# Altium schematic sheet TMC5160_BOB_V1_2.SchDoc(TMC5160_BOB_V1_2)
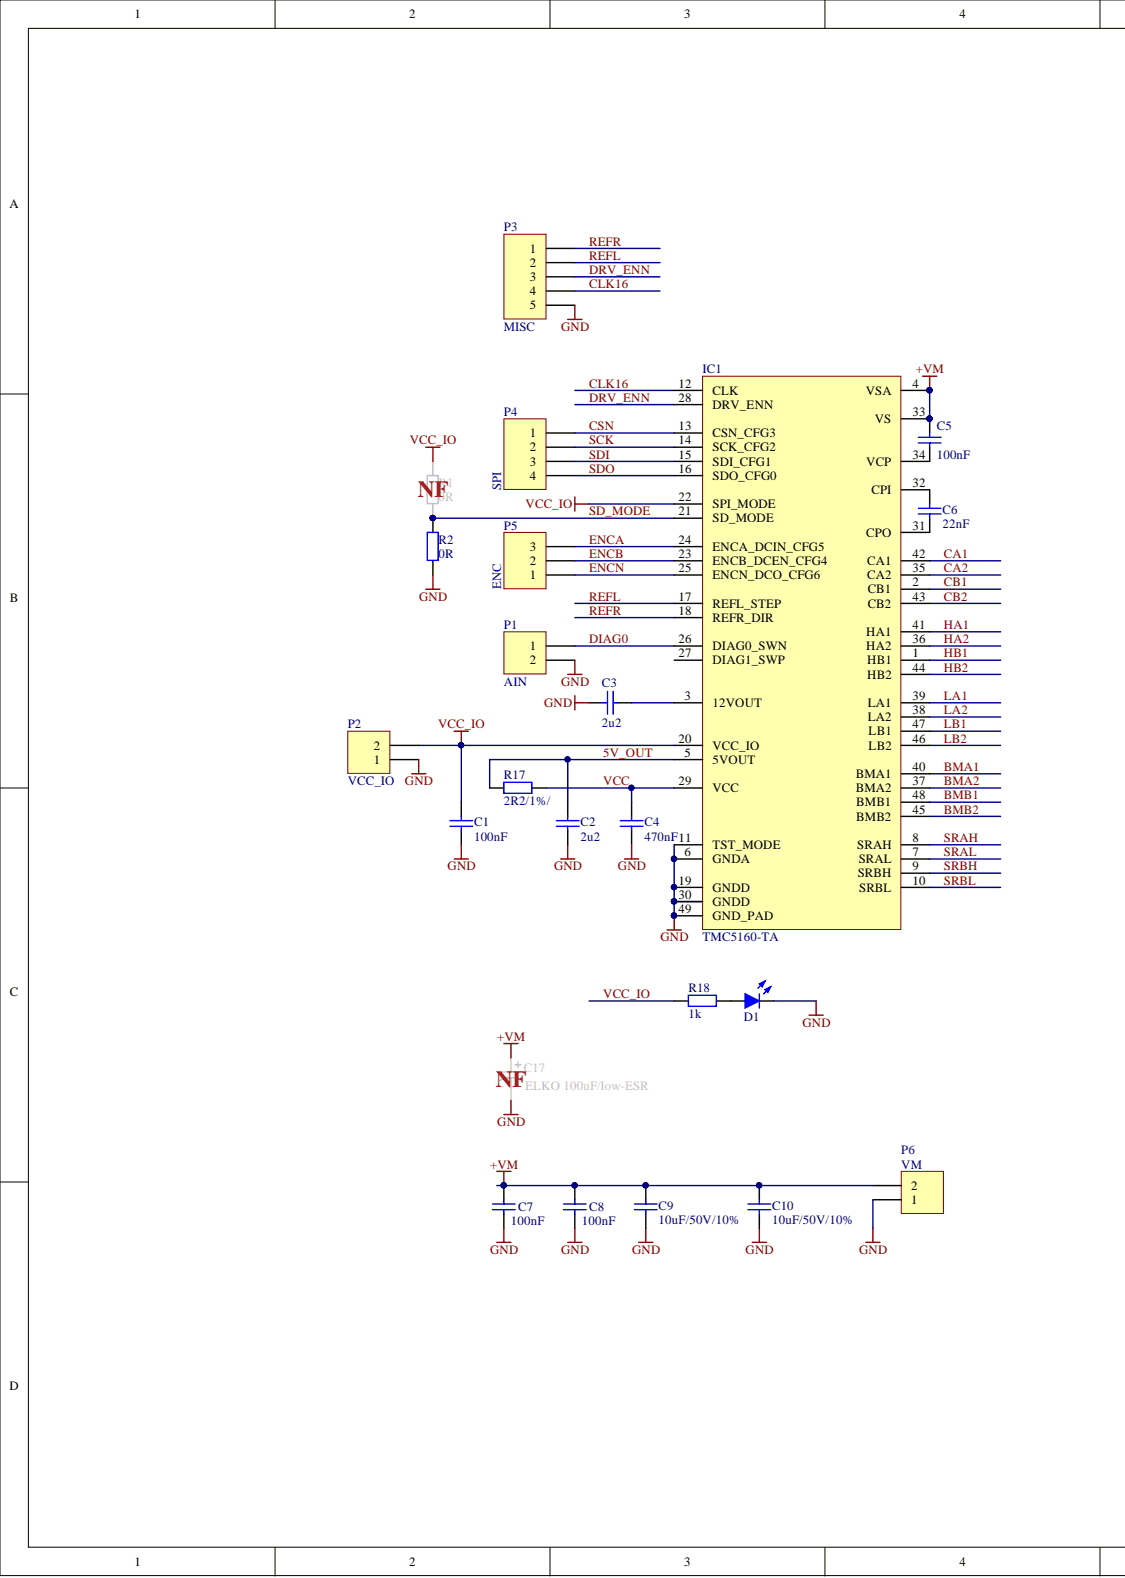
[diagram p0: TMC5160_BOB_V1_2.SchDoc, left]
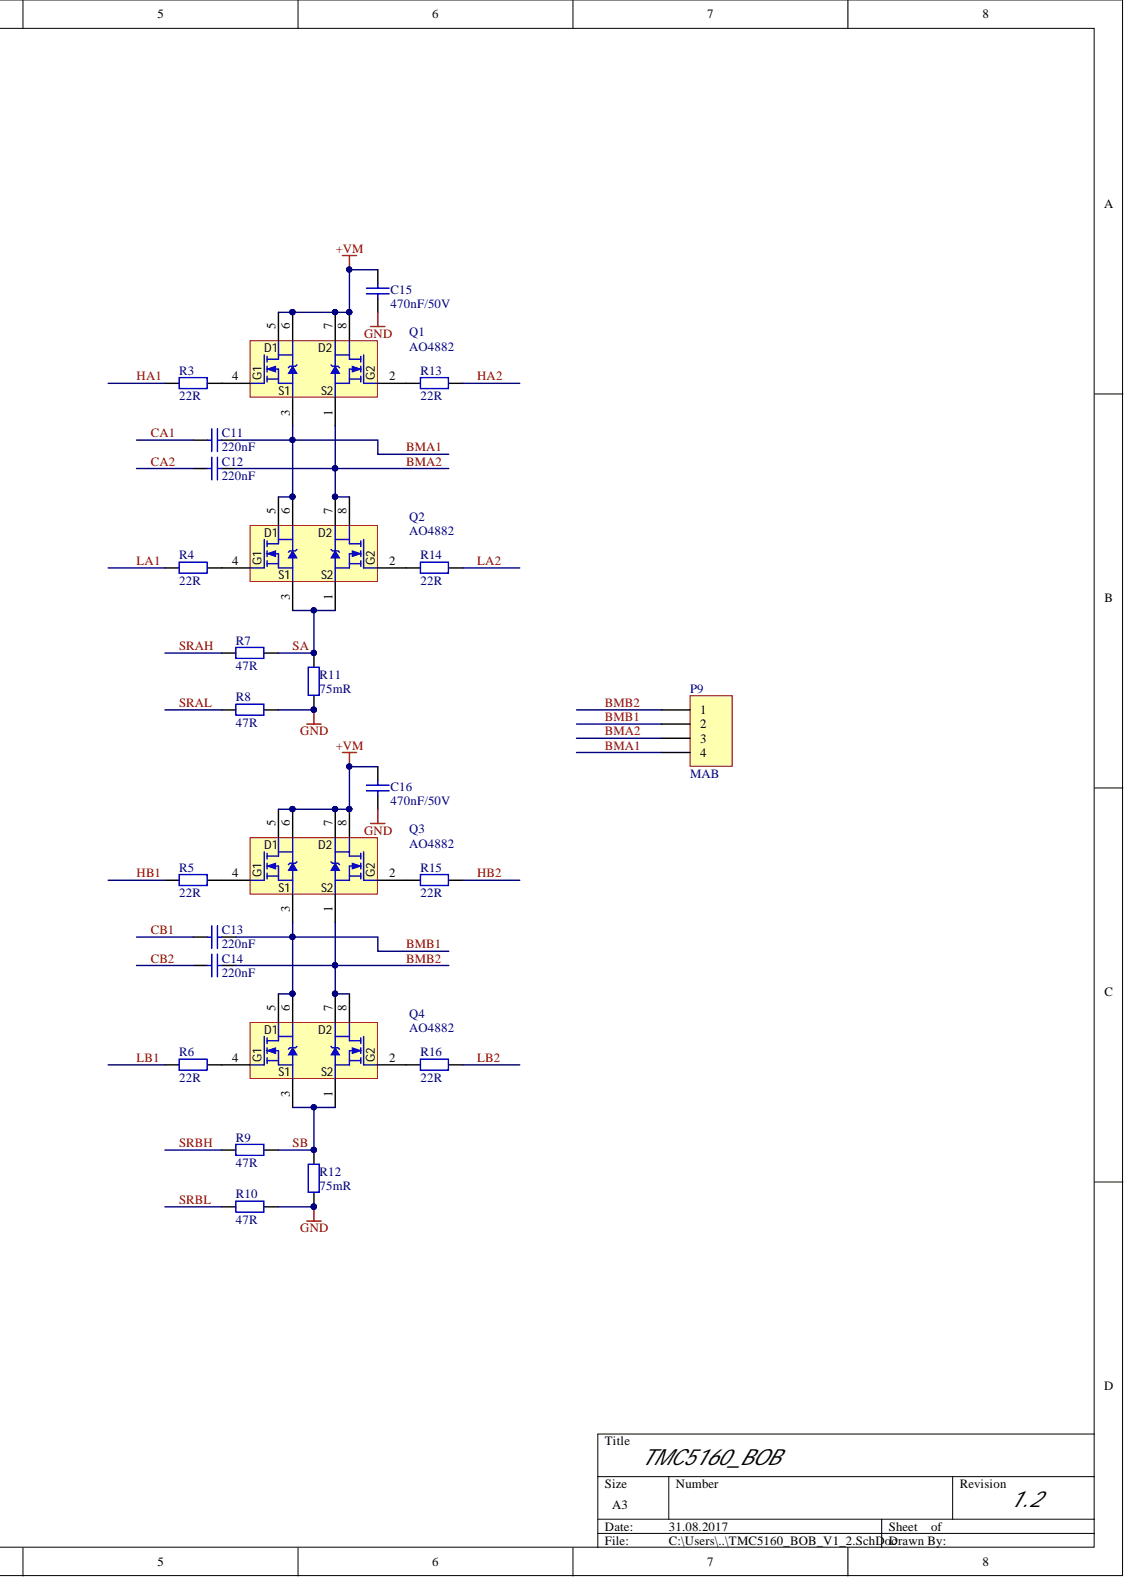
[diagram p1: TMC5160_BOB_V1_2.SchDoc, right]

## Parts
C1  100nF  [C0603]
C2  2u2  [C0603]
C3  2u2  [C0603]
C4  470nF  [C0603]
C5  100nF  [C0603]
C6  22nF  [C0603]
C7  100nF  [C0603]
C8  100nF  [C0603]
C9  10uF/50V/10%  [C1206]
C10  10uF/50V/10%  [C1206]
C11  220nF  [C0603]
C12  220nF  [C0603]
C13  220nF  [C0603]
C14  220nF  [C0603]
C15  470nF/50V  [C0603]
C16  470nF/50V  [C0603]
C17  value ELKO 100uF/low-ESR  [EXT_POL_CAP]  (hidden comment: Cap Pol1)
D1  LED, Blue, SMD, 20mA, 2.8V, 465 nm  [LED_0603]  (value not drawn)
IC1  TMC5160-TA  [TMC5160_TSQFP50P900X900X120_HS-49L]
P1  AIN  [2_PIN_THT]
P2  VCC_IO  [2_PIN_THT]
P3  MISC  [5_PIN_THT]
P4  SPI  [4_PIN_THT]
P5  ENC  [3_PIN_THT]
P6  VM  [2_PIN_THT]
P9  MAB  [4_PIN_THT_1mm_hole]
Q1  AO4882  [SOP127P600X170-8L]
Q2  AO4882  [SOP127P600X170-8L]
Q3  AO4882  [SOP127P600X170-8L]
Q4  AO4882  [SOP127P600X170-8L]
R1  0R  [R0603]
R2  0R  [R0603]
R3  Resistance: 22R  [R0603]  (hidden comment: 22R / 100mW / 1%)
R4  Resistance: 22R  [R0603]  (hidden comment: 22R / 100mW / 1%)
R5  Resistance: 22R  [R0603]  (hidden comment: 22R / 100mW / 1%)
R6  Resistance: 22R  [R0603]  (hidden comment: 22R / 100mW / 1%)
R7  Resistance: 47R  [R0603]  (hidden comment: 47R /  / 1%)
R8  Resistance: 47R  [R0603]  (hidden comment: 47R /  / 1%)
R9  Resistance: 47R  [R0603]  (hidden comment: 47R /  / 1%)
R10  Resistance: 47R  [R0603]  (hidden comment: 47R /  / 1%)
R11  75mR  [R1206]
R12  75mR  [R1206]
R13  Resistance: 22R  [R0603]  (hidden comment: 22R / 100mW / 1%)
R14  Resistance: 22R  [R0603]  (hidden comment: 22R / 100mW / 1%)
R15  Resistance: 22R  [R0603]  (hidden comment: 22R / 100mW / 1%)
R16  Resistance: 22R  [R0603]  (hidden comment: 22R / 100mW / 1%)
R17  2R2/1%/  [R0603]
R18  1k  [R0603]

## Nets
+VM: C5.1 C7.1 C8.1 C9.2 C10.2 C15.1 C16.1 C17.1 IC1.4(VSA) IC1.33(VS) P6.2 Q1.5 Q1.6 Q1.7 Q1.8 Q3.5 Q3.6 Q3.7 Q3.8
GND: C1.2 C2.2 C3.1 C4.2 C7.2 C8.2 C9.1 C10.1 C15.2 C16.2 C17.2 D1.2 IC1.6(GNDA) IC1.11(TST_MODE) IC1.19(GNDD) IC1.30(GNDD) IC1.49(GND_PAD) P1.2 P2.1 P3.5 P6.1 R2.2 R8.1 R10.1 R11.2 R12.2
5V_OUT: C2.1 IC1.5(5VOUT) R17.1
BMA1: C11.2 IC1.40(BMA1) P9.4 Q1.3 Q2.5 Q2.6
BMA2: C12.2 IC1.37(BMA2) P9.3 Q1.1 Q2.7 Q2.8
BMB1: C13.2 IC1.48(BMB1) P9.2 Q3.3 Q4.5 Q4.6
BMB2: C14.2 IC1.45(BMB2) P9.1 Q3.1 Q4.7 Q4.8
CA1: C11.1 IC1.42(CA1)
CA2: C12.1 IC1.35(CA2)
CB1: C13.1 IC1.2(CB1)
CB2: C14.1 IC1.43(CB2)
CLK16: IC1.12(CLK) P3.4
CSN: IC1.13(CSN_CFG3) P4.1
DIAG0: IC1.26(DIAG0_SWN) P1.1
DRV_ENN: IC1.28(DRV_ENN) P3.3
ENCA: IC1.24(ENCA_DCIN_CFG5) P5.3
ENCB: IC1.23(ENCB_DCEN_CFG4) P5.2
ENCN: IC1.25(ENCN_DCO_CFG6) P5.1
HA1: IC1.41(HA1) R3.2
HA2: IC1.36(HA2) R13.1
HB1: IC1.1(HB1) R5.2
HB2: IC1.44(HB2) R15.1
LA1: IC1.39(LA1) R4.2
LA2: IC1.38(LA2) R14.1
LB1: IC1.47(LB1) R6.2
LB2: IC1.46(LB2) R16.1
REFL: IC1.17(REFL_STEP) P3.2
REFR: IC1.18(REFR_DIR) P3.1
SA: Q2.1 Q2.3 R7.1 R11.1
SB: Q4.1 Q4.3 R9.1 R12.1
SCK: IC1.14(SCK_CFG2) P4.2
SD_MODE: IC1.21(SD_MODE) R1.2 R2.1
SDI: IC1.15(SDI_CFG1) P4.3
SDO: IC1.16(SDO_CFG0) P4.4
SRAH: IC1.8(SRAH) R7.2
SRAL: IC1.7(SRAL) R8.2
SRBH: IC1.9(SRBH) R9.2
SRBL: IC1.10(SRBL) R10.2
VCC: C4.1 IC1.29(VCC) R17.2
VCC_IO: C1.1 IC1.20(VCC_IO) IC1.22(SPI_MODE) P2.2 R1.1 R18.1
NetC3_2: C3.2 IC1.3(12VOUT)
NetC5_2: C5.2 IC1.34(VCP)
NetC6_1: C6.1 IC1.31(CPO)
NetC6_2: C6.2 IC1.32(CPI)
NetD1_1: D1.1 R18.2
NetQ1_2: Q1.2 R13.2
NetQ1_4: Q1.4 R3.1
NetQ2_2: Q2.2 R14.2
NetQ2_4: Q2.4 R4.1
NetQ3_2: Q3.2 R15.2
NetQ3_4: Q3.4 R5.1
NetQ4_2: Q4.2 R16.2
NetQ4_4: Q4.4 R6.1
N00027:

Not connected: IC1 27(DIAG1_SWP)

## Library data of the parts
C1 C7 C8 = Capacitor: Ceramic capacitor; MPN: MC0603F104M500CT; Manufacturer: Multicomp; Voltage rating: 50V; Type: MLCC; Precision: 20%; ComponentLinknDescription: Datasheet; Library Name: SCH Passives.SchLib; Component Kind: Standard; Capacitance: 100nF
C2 C3 = 2.2 µF, 25 V, ± 10%, X5R, GRM Series: SMD Multilayer Ceramic Capacitor, 0603 [1608 Metric], 2.2 µF, 25 V, ± 10%, X5R, GRM Series; MPN: GRM188R61E225KA12D; Voltage rating: 50V; Type: MLCC; MF: MURATA; ComponentLinknDescription: Datasheet; Precision: 10%; Library Name: TMC5160_RevA.SchLib; Component Kind: Standard; Capacitance: 2u2
C4 = Capacitor: Ceramic capacitor; MPN: MC0603X474K160CT; Voltage rating: 16V; Type: MLCC; Precision: 10%; MF: Multicomp; ComponentLinknDescription: Datasheet; Library Name: SCH Passives.SchLib; Component Kind: Standard; Capacitance: 470nF
C5 = Capacitor: Ceramic capacitor; MPN: MC0603F104M500CT; Voltage rating: 50V; Type: MLCC; Precision: 20%; MF: Multicomp; ComponentLinknDescription: Datasheet; Library Name: SCH Passives.SchLib; Component Kind: Standard; Capacitance: 100nF
C6 = Capacitor: Ceramic capacitor; MPN: MC0603B223K500CT; Voltage rating: 50V; Type: MLCC; Precision: 20%; MF: Multicomp; ComponentLinknDescription: Datasheet; Library Name: SCH Passives.SchLib; Component Kind: Standard; Capacitance: 22nF
C9 C10 = 10 µF, 50 V, ± 20%, X5R, GRM Series: SMD Multilayer Ceramic Capacitor, 1206 [3216 Metric], 10 µF, 50 V, ± 20%, X5R, GRM Series; MPN: GRM31CR61H106MA12L; VoltageRating: 50V; Type: MLCC; Precision: 10%; MF: MURATA; ComponentLinknDescription: Datasheet; Capacitance: 10uF; Library Name: TMC262_FDD8424H_RevA.SchLib; Component Kind: Standard
C11-C14 = 0.22 µF, 50 V, ± 10%, X7R: SMD Multilayer Ceramic Capacitor, 0603 [1608 Metric], 0.22 µF, 50 V, ± 10%, X7R, GCM Series; MPN: GCM188R71H224KA64D; Voltage rating: 50V; Type: MLCC; MF: MURATA; ComponentLinknDescription: Datasheet; Precision: 10%; Library Name: TMC5160_RevA.SchLib; Component Kind: Standard; Capacitance: 220nF
C15 C16 = 0.47 µF, 50 V, ± 10%, X7R, C Series: SMD Multilayer Ceramic Capacitor, 0603 [1608 Metric], 0.47 µF, 50 V, ± 10%, X7R, C Series; MPN: C1608X7R1H474K080AC; VoltageRating: 50V; Type: MLCC; MF: TDK; ComponentLinknDescription: Datasheet; Capacitance: 470nF; Precision: 10%; Library Name: TMC5160_RevA.SchLib; Component Kind: Standard
C17 = Cap Pol1: Polarized Capacitor (Radial); Published: 8-Jun-2000; PackageReference: RB7.6-15; PackageDescription: Polarized Capacitor (Radial); 2 Leads; Library Name: Miscellaneous Devices.IntLib; LatestRevisionNote: Re-released for DXP Platform.; LatestRevisionDate: 17-Jul-2002; Component Kind: Standard; Publisher: Altium Limited
D1 = LED, Blue, SMD, 20mA, 2.8V, 465 nm: MPN: LTST-C191TBKT-5A; MF: Lite-On; Library Name: TMC26x_RevA.SchLib; Description: LED, Blue, SMD, 20mA, 2.8V, 465 nm; Current rating: 20mA; Component Kind: Standard; Colour: Blue
IC1 = TMC5160-TA: MF: TRINAMIC; Geometry.Height: 1,2mm; MPN: TMC5160-TA; Manufacturer: TRINAMIC; Library Name: TMC5160_RevA.SchLib; Distributor#1: Digikey; Component Kind: Standard
P1 P2 P6 = Header 2: Header, 2-Pin; Fitted: Not fitted; Signal Integrity: Connector; Library Name: Miscellaneous Connectors.IntLib; LatestRevisionNote: Re-released for DXP Platform.; LatestRevisionDate: 17-Jul-2002; Component Kind: Standard; ComponentClass: Pinheader; Publisher: Altium Limited
P3 = Header 5: Header, 5-Pin; Fitted: Not fitted; Signal Integrity: Connector; Library Name: Miscellaneous Connectors.IntLib; LatestRevisionNote: Re-released for DXP Platform.; LatestRevisionDate: 17-Jul-2002; Component Kind: Standard; Publisher: Altium Limited
P4 = Header 4: Header, 4-Pin; Fitted: Not fitted; Signal Integrity: Connector; Library Name: Miscellaneous Connectors.IntLib; LatestRevisionNote: Re-released for DXP Platform.; LatestRevisionDate: 17-Jul-2002; Component Kind: Standard; ComponentClass: Pinheader; Publisher: Altium Limited
P5 = Header 3: Header, 3-Pin; Fitted: Not fitted; Signal Integrity: Connector; Library Name: Miscellaneous Connectors.IntLib; LatestRevisionNote: Re-released for DXP Platform.; LatestRevisionDate: 17-Jul-2002; Component Kind: Standard; Publisher: Altium Limited
P9 = Wire-To-Board Connector, 2.5 mm, 4 Contacts, Header, EH Series, Through Hole, 1 Rows: MPN: B4B-EH-A; MF: JST (JAPAN SOLDERLESS TERMINALS); Fitted: Not fitted; Signal Integrity: Connector; Library Name: TMC5160_RevA.SchLib; Description: Wire-To-Board Connector, 2.5 mm, 4 Contacts, Header, EH Series, Through Hole, 1 Rows; Component Kind: Standard
Q1-Q4 = AO4882 MOSFET 2N-CH 40V 8A 8SOIC: Mosfet Array 2 N-Channel (Dual) 40V 8A 2W Surface Mount 8-SOIC; MF: Alpha & Omega Semiconductor Inc.; MPN: AO4882; Library Name: TMC5160_RevA.SchLib; Component Kind: Standard
R1 R2 = 0 ohm, 0603 [1608 Metric], 100 mW, MCWR Series: SMD Chip Resistor, Thick Film, 0 ohm, 0603 [1608 Metric], 100 mW, MCWR Series; MPN: MCWR06X000 PTL; Temp coefficient: *ppm; PowerRating: 100mW; MF: MULTICOMP; Resistance: 0R; Library Name: TMC5160_RevA.SchLib; Component Kind: Standard
R3-R6 R13-R16 = 22 ohm, 50 V, 0603 [1608 Metric], 100 mW, ± 1%, MCWR Series: SMD Chip Resistor, Thick Film, 22 ohm, 50 V, 0603 [1608 Metric], 100 mW, ± 1%, MCWR Series; MPN: MCWR06X22R0FTLV; Temp coefficient: *ppm; MF: MULTICOMP; Precision: 1%; PowerRating: 100mW; Library Name: TMC5160_RevA.SchLib; Component Kind: Standard
R7-R10 = 47 ohm, 75 V, 0603 [1608 Metric], 100 mW, ± 1%, MCSR 06 Series: SMD Chip Resistor, Ceramic, 47 ohm, 75 V, 0603 [1608 Metric], 100 mW, ± 1%, MCSR 06 Series; MPN: MCSR06X47R0FTL; Temp coefficient: *ppm; MF: MULTICOMP; Precision: 1%; Library Name: TMC5160_RevA.SchLib; Component Kind: Standard
R11 R12 = R_SENS, 0.075 ohm, 1206, 1W, ± 1%, ERJ Series: SMD Chip Resistor, Thick Film, Current Sense Resistors - SMD 1W 0.075ohm 1%; MPN: ERJ-8BWFR075V; VoltageRating: 50V; Temp coefficient: *ppm; MF: Panasonic Electronic Components; Resistance: 75mR; Precision: 1%; PowerRating: 1W; Library Name: SMD_Passives.SchLib; Component Kind: Standard
R17 = Resistor: MPN: MC0063W060312R2; Voltage rating: *V; Temp coefficient: *ppm; Resistance: 2R2; Precision: 1%; Power rating: *mW; MF: Multicomp; ComponentLinknDescription: Datasheet; Library Name: SCH Passives.SchLib; Description: Resistor; Component Kind: Standard
R18 = 1 kohm, 50 V, 0603 [1608 Metric], 63 mW, ± 1%, MC Series: MPN: MC0063W060311K; VoltageRating: 50V; Temp coefficient: *ppm; PowerRating: 63mW; MF: MULTICOMP; Resistance: 1k; Precision: 1%; Library Name: TMC457_RevA.SchLib; Description: 1 kohm, 50 V, 0603 [1608 Metric], 63 mW, ± 1%, MC Series; Component Kind: Standard
